# Altium schematic sheet AUDIO_CODEC.SchDoc
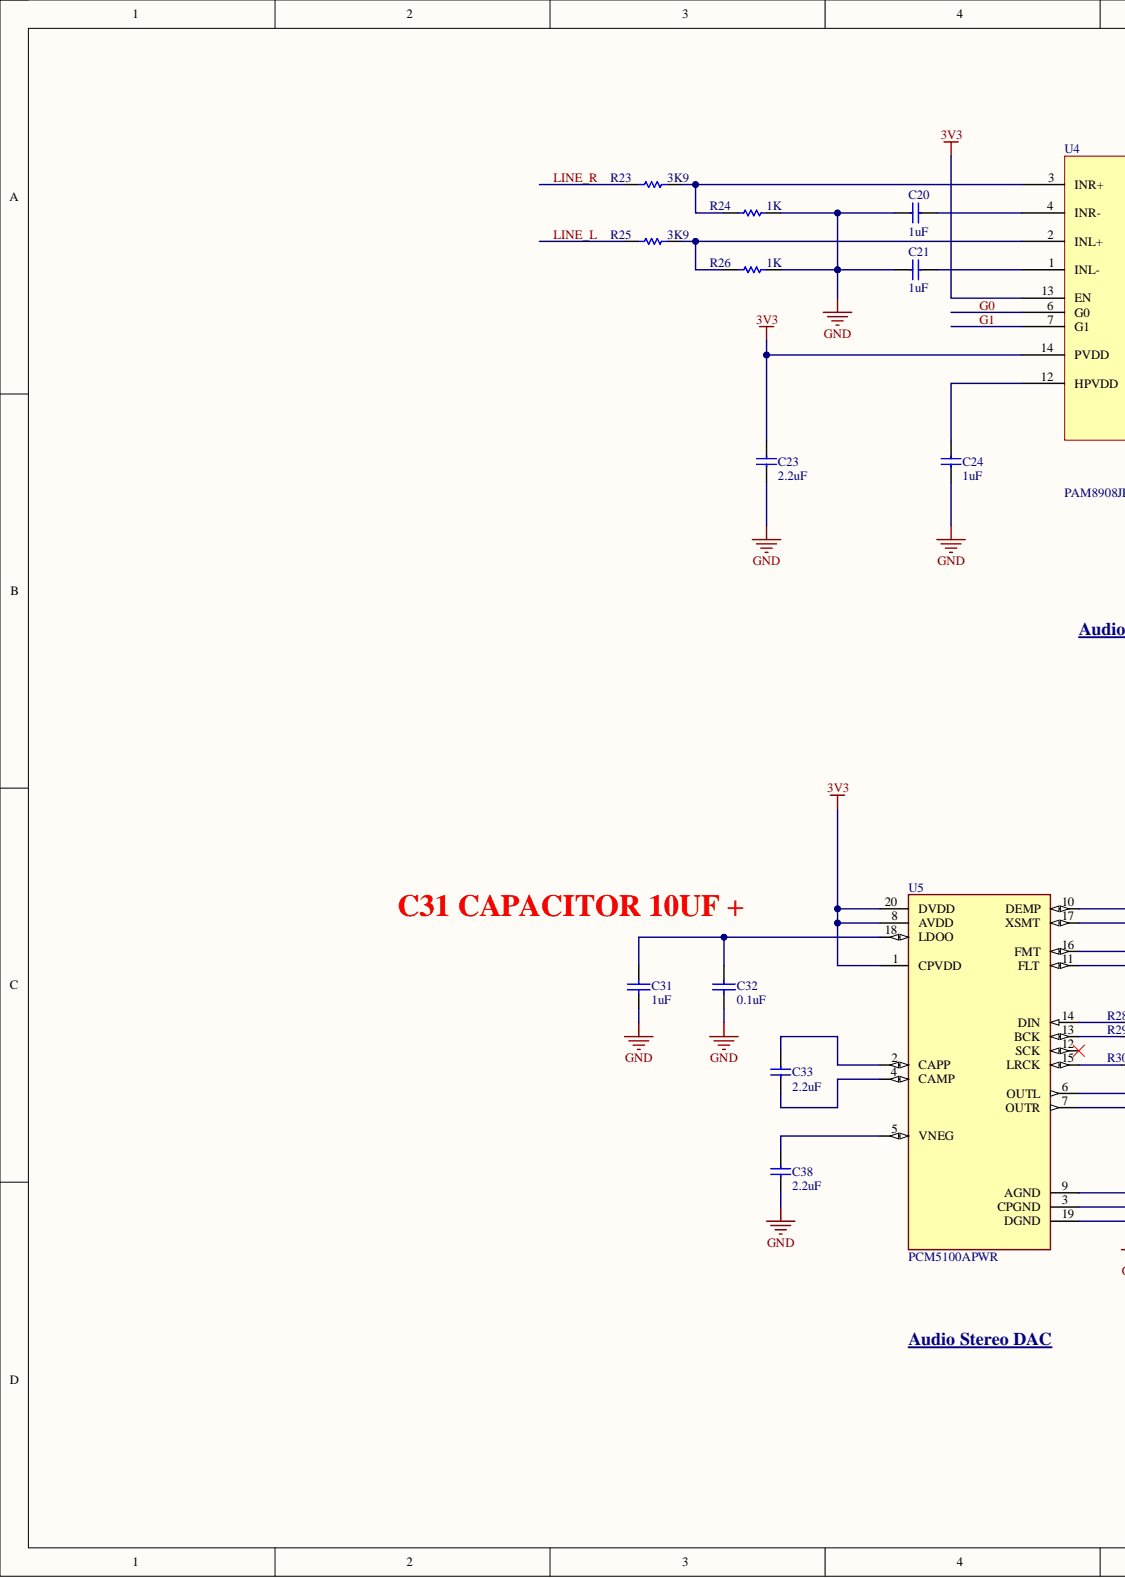
[diagram p0: AUDIO_CODEC.SchDoc, left]
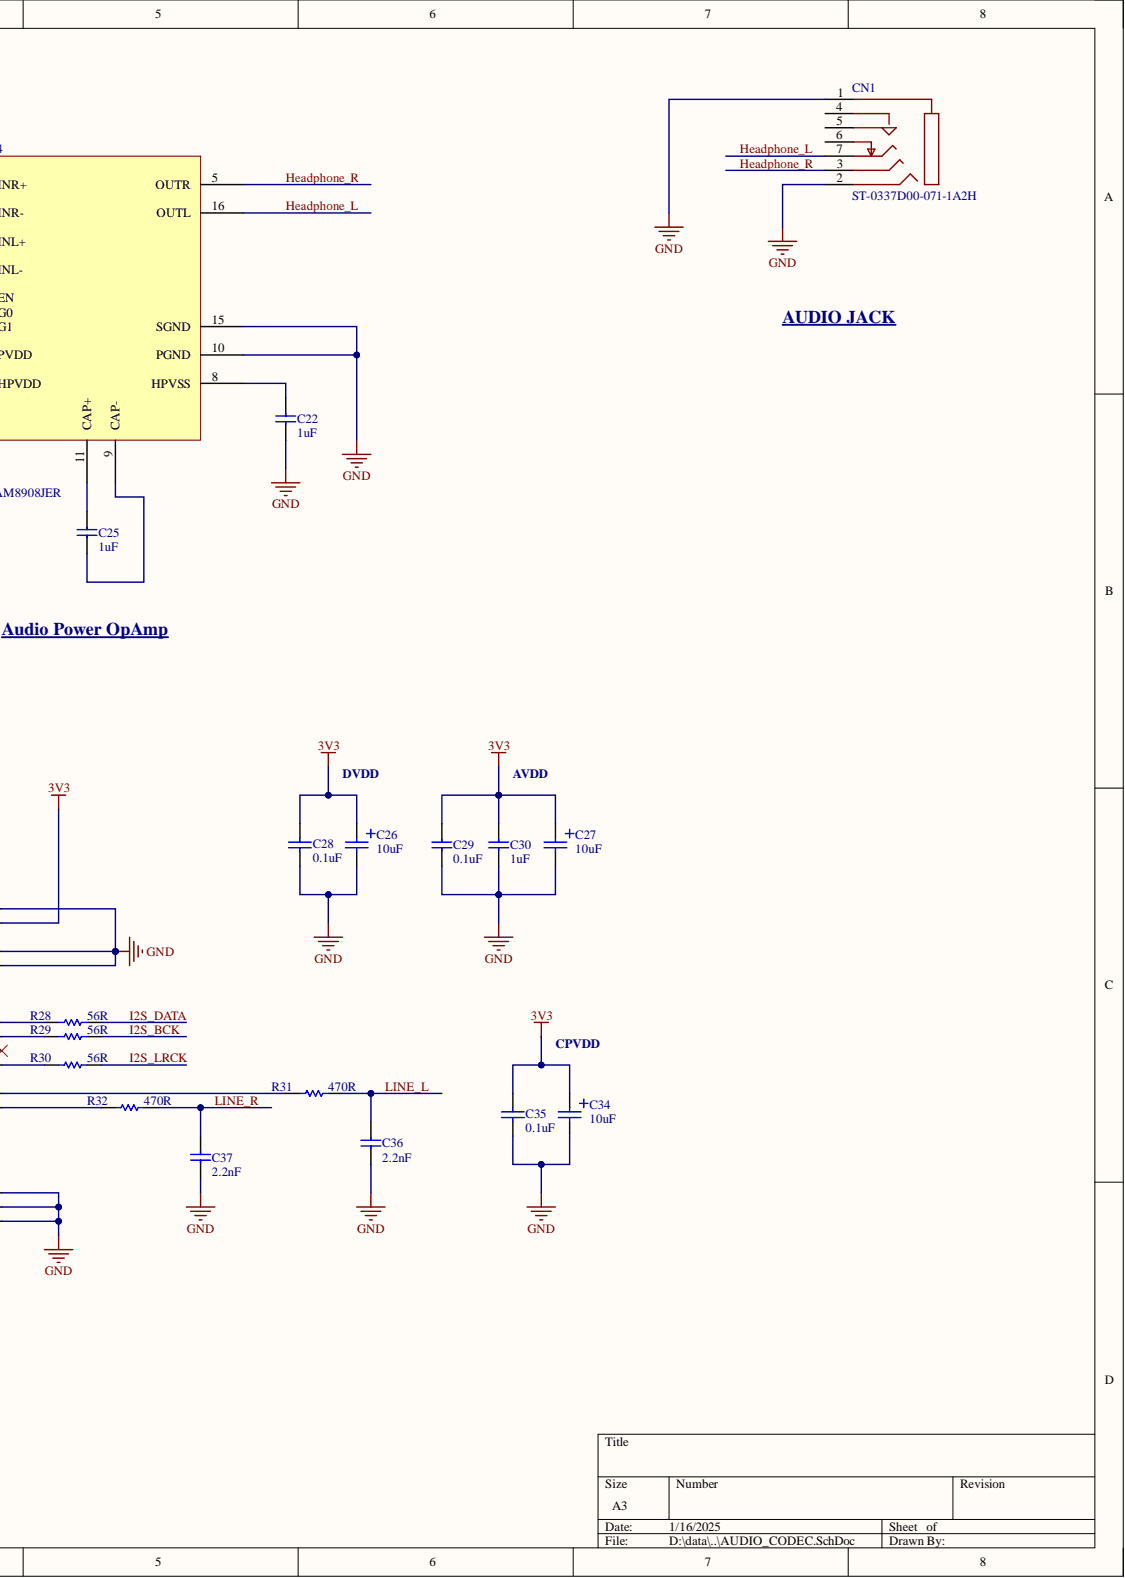
[diagram p1: AUDIO_CODEC.SchDoc, right]

## Parts
C20  1uF  [CAPC1005X04L]
C21  1uF  [CAPC1005X04L]
C22  1uF  [CAPC1005X04L]
C23  2.2uF  [CAPC1005X04L]
C24  1uF  [CAPC1005X04L]
C25  1uF  [CAPC1005X04L]
C26  10uF  [C0805+]
C27  10uF  [C0805+]
C28  0.1uF  [C0603]
C29  0.1uF  [CAPC1005X04L]
C30  1uF  [CAPC1005X04L]
C31  1uF  [C0603]
C32  0.1uF  [C0603]
C33  2.2uF  [CAPC1005X04L]
C34  10uF  [C0805+]
C35  0.1uF  [C0603]
C36  2.2nF  [CAPC1005X04L]
C37  2.2nF  [CAPC1005X04L]
C38  2.2uF  [CAPC1005X04L]
CN1  ST-0337D00-071-1A2H  [ST-0337D00-071-1A2H]
R23  3K9  [R0402]
R24  1K  [R0402]
R25  3K9  [R0402]
R26  1K  [R0402]
R28  56R  [R0603]
R29  56R  [R0603]
R30  56R  [R0603]
R31  470R  [R0402]
R32  470R  [R0402]
U4  PAM8908JER  [U-QFN3030-16]
U5  PCM5100APWR  [TSSOP-20]

## Nets
3V3: C23.2 C26.1 C27.1 C28.2 C29.2 C30.2 C34.1 C35.2 U4.13(EN) U4.14(PVDD) U5.1(CPVDD) U5.8(AVDD) U5.17(XSMT) U5.20(DVDD)
GND: C20.2 C21.2 C22.1 C23.1 C24.1 C26.2 C27.2 C28.1 C29.1 C30.1 C31.1 C32.1 C34.2 C35.1 C36.1 C37.1 C38.1 CN1.1 CN1.2 R24.2 R26.2 U4.10(PGND) U4.15(SGND) U5.3(CPGND) U5.9(AGND) U5.10(DEMP) U5.11(FLT) U5.16(FMT) U5.19(DGND)
G0: U4.6(G0)
G1: U4.7(G1)
Headphone_L: CN1.7 U4.16(OUTL)
Headphone_R: CN1.3 U4.5(OUTR)
I2S_BCK: R29.2
I2S_DATA: R28.2
I2S_LRCK: R30.2
LINE_L: C36.2 R25.1 R31.2
LINE_R: C37.2 R23.1 R32.2
NetC20_1: C20.1 U4.4(INR-)
NetC21_1: C21.1 U4.1(INL-)
NetC22_2: C22.2 U4.8(HPVSS)
NetC24_2: C24.2 U4.12(HPVDD)
NetC25_1: C25.1 U4.9(CAP-)
NetC25_2: C25.2 U4.11(CAP+)
NetC31_2: C31.2 C32.2 U5.18(LDOO)
NetC33_1: C33.1 U5.4(CAMP)
NetC33_2: C33.2 U5.2(CAPP)
NetC38_2: C38.2 U5.5(VNEG)
NetR23_2: R23.2 R24.1 U4.3(INR+)
NetR25_2: R25.2 R26.1 U4.2(INL+)
NetR28_1: R28.1 U5.14(DIN)
NetR29_1: R29.1 U5.13(BCK)
NetR30_1: R30.1 U5.15(LRCK)
NetR31_1: R31.1 U5.6(OUTL)
NetR32_1: R32.1 U5.7(OUTR)

Not connected: CN1 4 5 6; U5 12(SCK)

## Library data of the parts
C20-C25 C29 C30 C33 C36-C38 = C0402: Capacitor, X5R, ±5%, 6.3V; Manufacturer: N.A.; Part Number: C0402-1µ0; Part number IPS: 00000007786, 00000003457; Artikkelnr Noca: 20039235; Pad Count: 2; Value: 1.0µF; Component Class: Capacitors; Component Kind: Standard; ComponentLink1Description: Datasheet; ComponentLink1URL: N.A.; ComponentLink2Description: Supplier link; ComponentLink2URL: N.A.; Footprint Doc: 0402; HelpURL: N.A.; LatestRevisionDate: 2016-08-22; Library Name: my_library.SchLib; Manufacturer Part Number: N.A.; Note: 6.3V; PartId: 100200190; Published: 2009-05-08; Supplier 1: Digi-Key; Supplier 2: N.A.; Supplier Part Number 1: 1276-1444-1-ND; Supplier Part Number 2: N.A.
C26 C27 C34 = tantalum_capacitor_0805: Component Kind: Standard; Library Name: my_library.SchLib
C28 C31 C32 C35 = C0603: Capacitor; Component Kind: Standard; Library Name: my_library.SchLib
R23-R26 R31 R32 = R0402: Resistor; Component Kind: Standard; Library Name: my_library.SchLib
R28-R30 = R0603: Resistor; Component Kind: Standard; Library Name: my_library.SchLib
U4 = PAM8908JER: 2Channel 35mWx2@16Ω Class AB QFN-16-EP(3x3) Audio Power OpAmps ROHS; Component Kind: Standard; Library Name: my_library.SchLib
U5 = PCM5100APWR: 2VRMS DirectPath™, 100dB Audio Stereo DAC with 32-bit, 384kHz PCM Interface; MF: Texas Instruments; MP: PCM5100PW; Availability: Unavailable; Component Kind: Standard; Library Name: my_library.SchLib; Package: TSSOP-20 Texas Instruments; Price: None; SnapEDA_Link: https://www.snapeda.com/parts/PCM5100PW/Texas%20Instruments/view-part/597448/?ref=snap
CN1 = ST-0337D00-071-1A2H: Component Kind: Standard; Library Name: my_library.SchLib
